# Altium schematic sheet STM32F407Demo.SchDoc
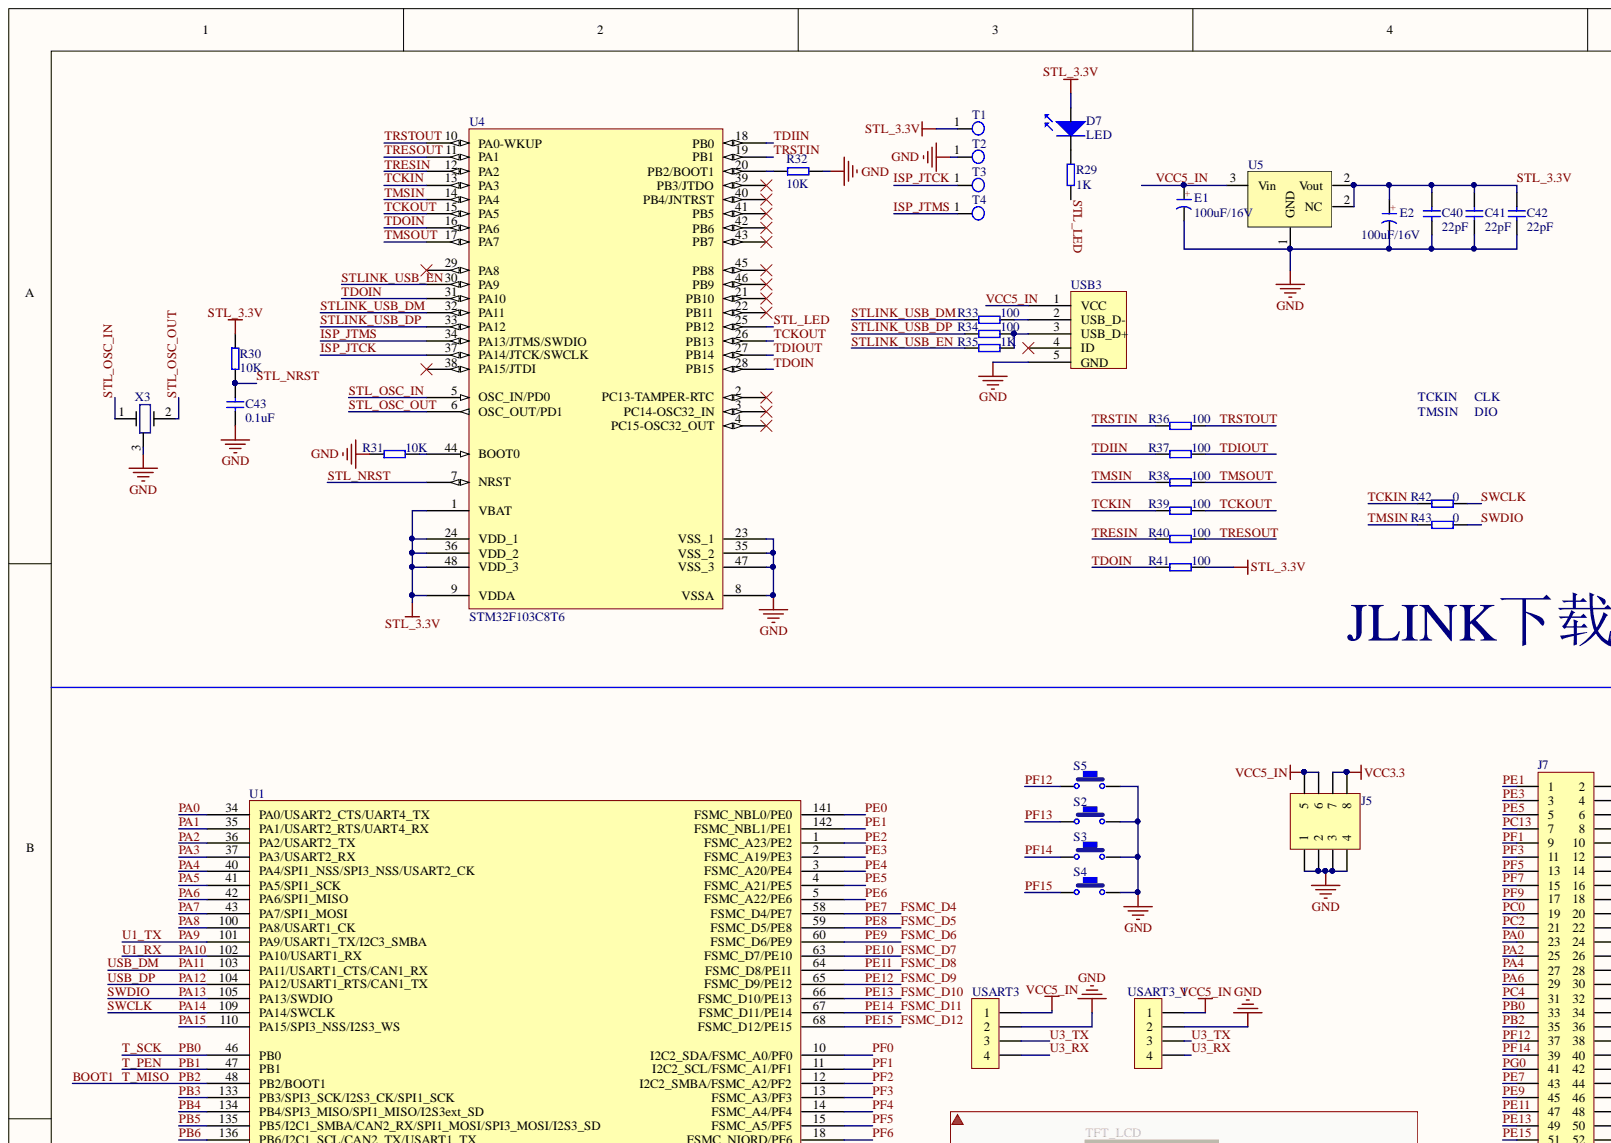
[diagram p0: STM32F407Demo.SchDoc, top left]
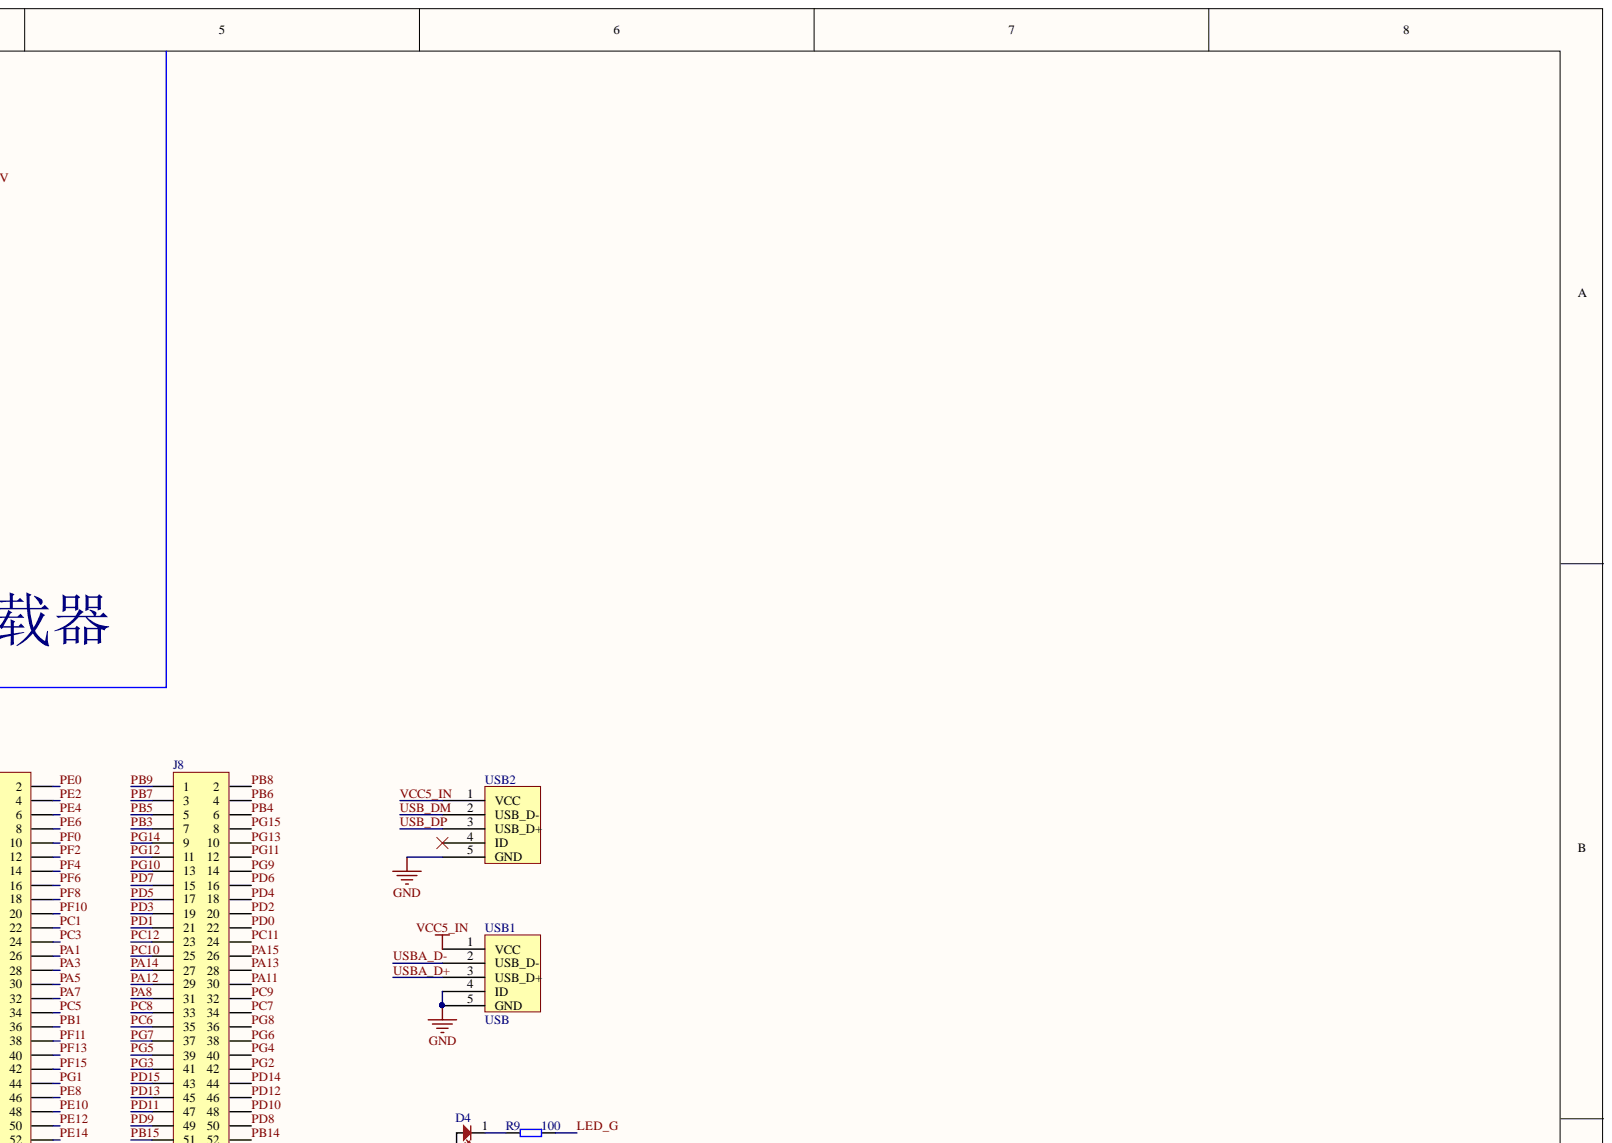
[diagram p1: STM32F407Demo.SchDoc, top right]
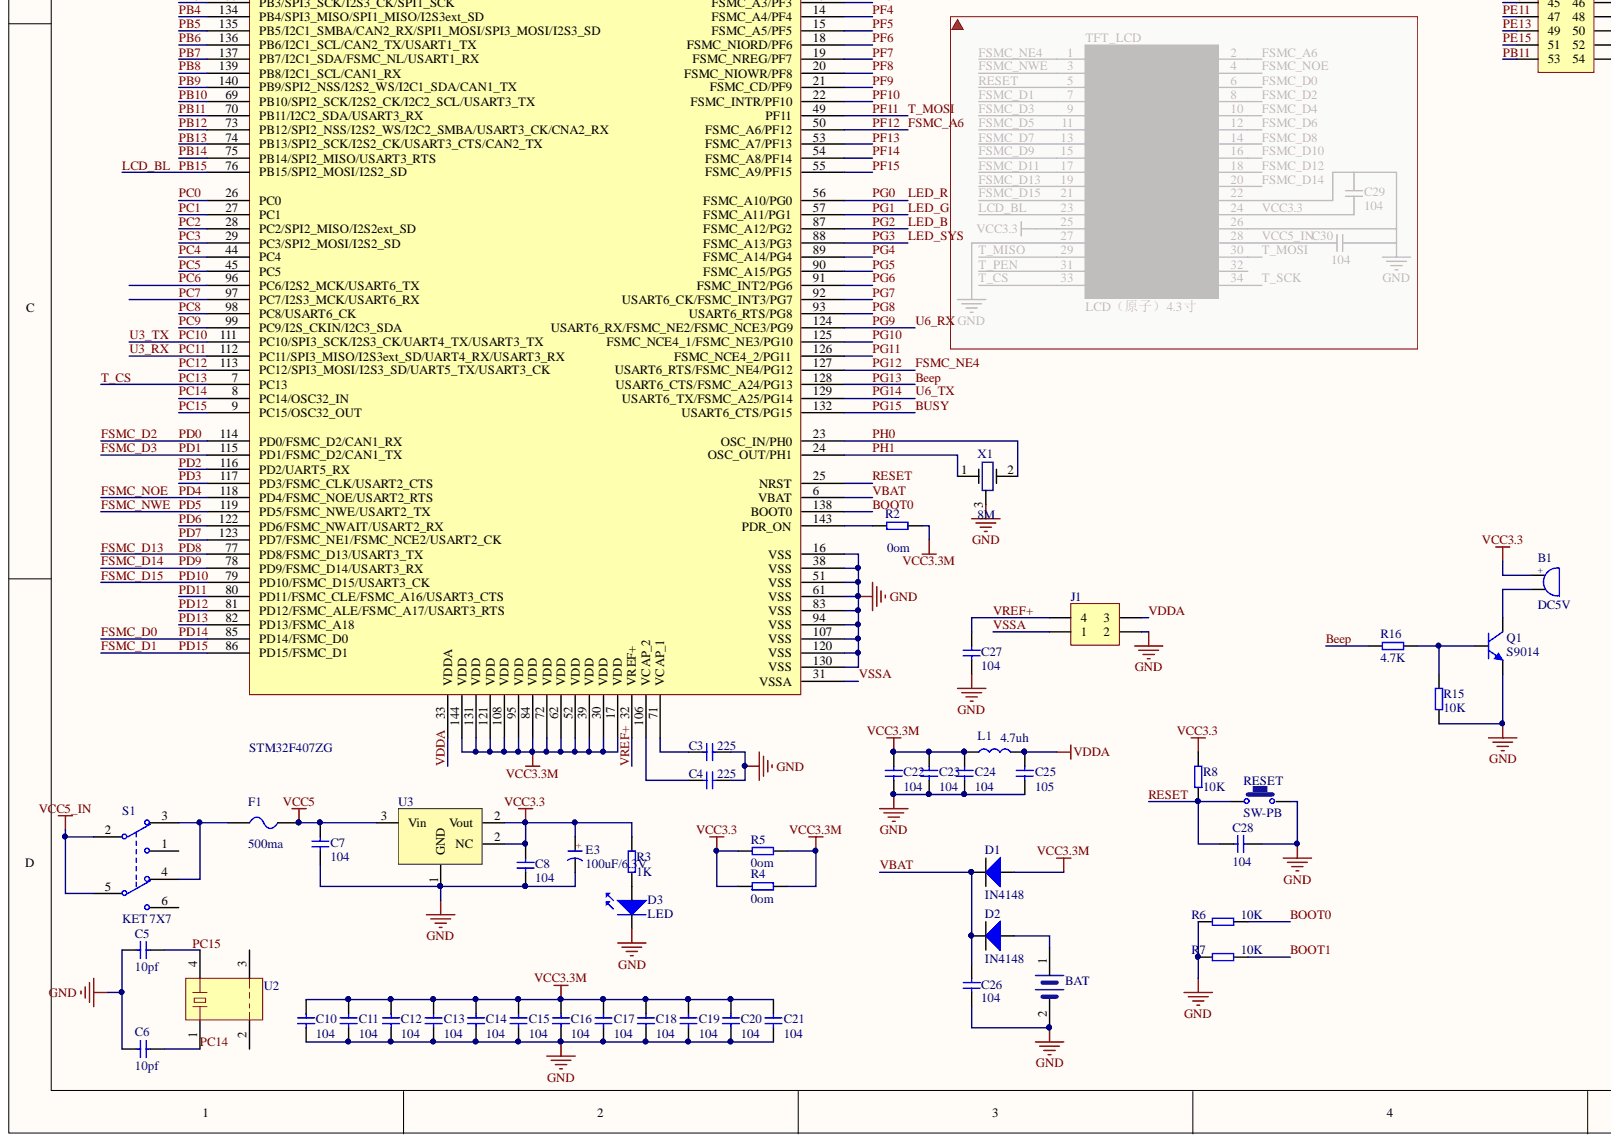
[diagram p2: STM32F407Demo.SchDoc, bottom left]
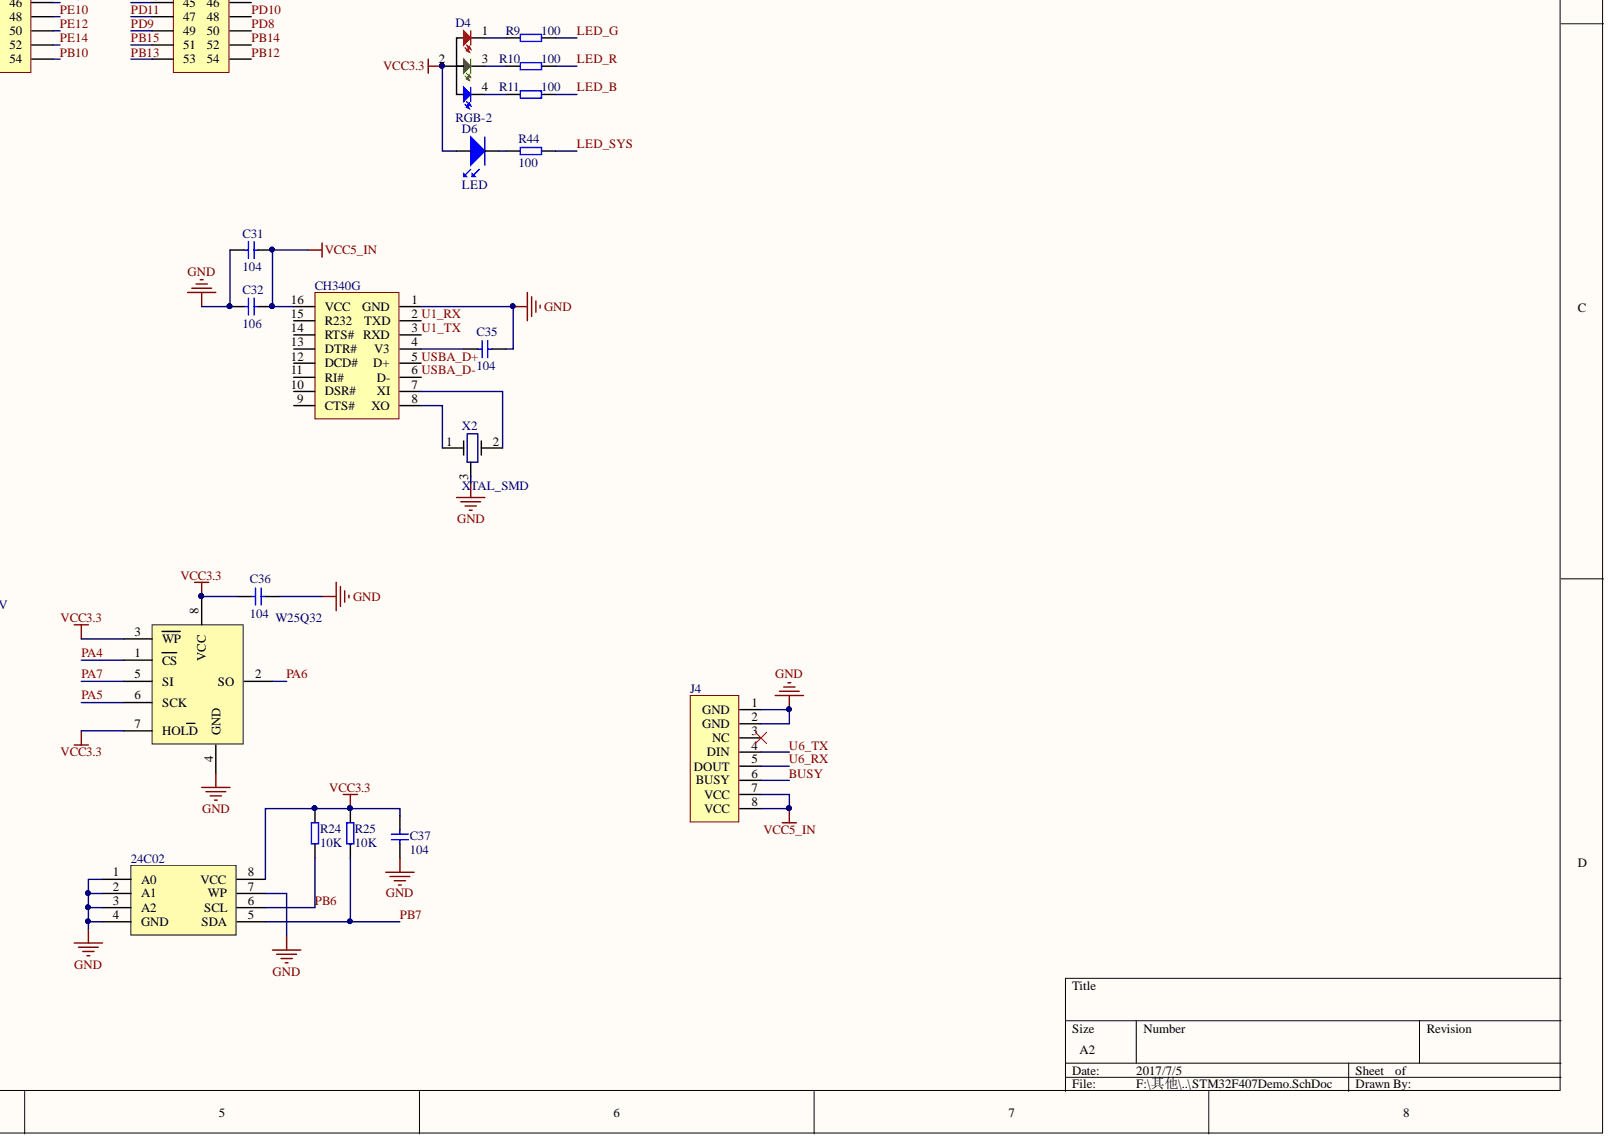
[diagram p3: STM32F407Demo.SchDoc, bottom right]

## Parts
24C02  (no value)  [SOP_8]
B1  DC5V  [BEEP 5x9x5.5]
BAT  (no value)  [BATM]
C3  225  [0603C]
C4  225  [0603C]
C5  10pf  [0603C]
C6  10pf  [0603C]
C7  104  [0603C]
C8  104  [0603C]
C10  104  [0603C]
C11  104  [0603C]
C12  104  [0603C]
C13  104  [0603C]
C14  104  [0603C]
C15  104  [0603C]
C16  104  [0603C]
C17  104  [0603C]
C18  104  [0603C]
C19  104  [0603C]
C20  104  [0603C]
C21  104  [0603C]
C22  104  [0603C]
C23  104  [0603C]
C24  104  [0603C]
C25  105  [0603C]
C26  104  [0603C]
C27  104  [0603C]
C28  104  [0603C]
C31  104  [0603C]
C32  106  [0603C]
C35  104  [0603C]
C36  104  [0603C]
C37  104  [0603C]
C40  22pF  [0603C]
C41  22pF  [0603C]
C42  22pF  [0603C]
C43  0.1uF  [0603C]
CH340G  (no value)  [SOP16_N]
D1  IN4148  [1206C]
D2  IN4148  [1206C]
D3  LED  [0603D]
D4  RGB-2  [RGB-2]
D6  LED  [0603D]
D7  LED  [0603D]
E1  100uF/16V  [CD C(6032)]
E2  100uF/16V  [CD C(6032)]
E3  100uF/6.3V  [CD C(6032)]
F1  500ma  [FU 0805]
J1  Header2*2  [Heaer2*2]  (value not drawn)
J4  LCD_Header*8  [PH2.0 S-W-8P]  (value not drawn)
J5  Header2*4  [Header 2*4]  (value not drawn)
J7  Header54  [Header54]  (value not drawn)
J8  Header54  [Header54]  (value not drawn)
L1  4.7uh  [0805L]
Q1  S9014  [SOT23-3N]
R2  0om  [0603R]
R3  1K  [0603R]
R4  0om  [0603R]
R5  0om  [0603R]
R6  10K  [0603R]
R7  10K  [0603R]
R8  10K  [0603R]
R9  100  [0603R]
R10  100  [0603R]
R11  100  [0603R]
R15  10K  [0603R]
R16  4.7K  [0603R]
R24  10K  [0603R]
R25  10K  [0603R]
R29  1K  [0603R]
R30  10K  [0603R]
R31  10K  [0603R]
R32  10K  [0603R]
R33  100  [0603R]
R34  100  [0603R]
R35  1K  [0603R]
R36  100  [0603R]
R37  100  [0603R]
R38  100  [0603R]
R39  100  [0603R]
R40  100  [0603R]
R41  100  [0603R]
R42  0  [0603R]
R43  0  [0603R]
R44  100  [0603R]
RESET  SW-PB  [Switch]
S1  KET 7X7  [KFT DIP-7X7]
S2  SW-PB  [Switch]  (value not drawn)
S3  SW-PB  [Switch]  (value not drawn)
S4  SW-PB  [Switch]  (value not drawn)
S5  SW-PB  [Switch]  (value not drawn)
T1  Test_Point  [TEST 1.2]  (value not drawn)
T2  Test_Point  [TEST 1.2]  (value not drawn)
T3  Test_Point  [TEST 1.2]  (value not drawn)
T4  Test_Point  [TEST 1.2]  (value not drawn)
U1  STM32F407ZG  [LQFP144 20X20_M]
U2  (no value)  [MC-306]
U3  AMS1117  [SOT223_N]  (value not drawn)
U4  STM32F103C8T6  [LQFP48 7X7_M]
U5  AMS1117  [SOT223_N]  (value not drawn)
USART3  Header4  [SMD-1.25]  (value not drawn)
USART3_1  Header4  [贴片焊盘 1.27]  (value not drawn)
USB1  USB  [USB_Micro]
USB2  USB  [USB_Micro]
USB3  USB  [USB_Micro]
W25Q32  (no value)  [SOP_8]
X1  8M  [XTAL_SMD]
X2  XTAL_SMD  [XTAL_SMD]
X3  XTAL_SMD  [XTAL_SMD]  (value not drawn)

## Nets
GND: 24C02.1(A0) 24C02.2(A1) 24C02.3(A2) 24C02.4(GND) 24C02.7(WP) BAT.2 C3.1 C4.1 C5.1 C6.1 C7.1 C8.1 C10.1 C11.1 C12.1 C13.1 C14.1 C15.1 C16.1 C17.1 C18.1 C19.1 C20.1 C21.1 C22.1 C23.1 C24.1 C25.1 C26.1 C27.1 C28.1 C31.2 C32.2 C35.1 C36.2 C37.1 C40.1 C41.1 C42.1 C43.1 CH340G.1(GND) D3.2 E1.2 E2.2 E3.2 J1.2 J4.1(GND) J4.2(GND) J5.1 J5.2 J5.3 J5.4 Q1.2 R6.2 R7.1 R15.1 R31.1 R32.2 RESET.2 S2.2 S3.2 S4.2 S5.2 T2.1 U1.16(VSS) U1.38(VSS) U1.51(VSS) U1.61(VSS) U1.83(VSS) U1.94(VSS) U1.107(VSS) U1.120(VSS) U1.130(VSS) U3.1 U4.8(VSSA) U4.23(VSS_1) U4.35(VSS_2) U4.47(VSS_3) U5.1 USART3.2 USART3_1.2 USB1.4(ID) USB1.5(GND) USB2.5(GND) USB3.5(GND) W25Q32.4(GND) X1.3 X2.3 X3.3
VCC3.3: 24C02.8(VCC) B1.1 C8.2 C36.1 C37.2 D4.2 D6.1 E3.1 J5.7 J5.8 R3.2 R4.1 R5.2 R8.2 R24.1 R25.1 U3.2 W25Q32.3(WP) W25Q32.7(HOLD) W25Q32.8(VCC)
VCC3.3M: C10.2 C11.2 C12.2 C13.2 C14.2 C15.2 C16.2 C17.2 C18.2 C19.2 C20.2 C21.2 C22.2 C23.2 C24.2 D1.1 L1.1 R2.2 R4.2 R5.1 U1.17(VDD) U1.30(VDD) U1.39(VDD) U1.52(VDD) U1.62(VDD) U1.72(VDD) U1.84(VDD) U1.95(VDD) U1.108(VDD) U1.121(VDD) U1.131(VDD) U1.144(VDD)
VCC5: C7.2 F1.2 U3.3
BEEP: J8.10 R16.1 U1.128(USART6_CTS/FSMC_A24/PG13)
BOOT0: R6.1 U1.138(BOOT0)
BOOT1: J7.35 R7.2 U1.48(PB2/BOOT1)
BUSY: J4.6(BUSY) J8.8 U1.132(USART6_CTS/PG15)
FSMC_A6: J7.37 S5.1 U1.50(FSMC_A6/PF12)
FSMC_D0: J8.44 U1.85(PD14/FSMC_D0)
FSMC_D1: J8.43 U1.86(PD15/FSMC_D1)
FSMC_D2: J8.22 U1.114(PD0/FSMC_D2/CAN1_RX)
FSMC_D3: J8.21 U1.115(PD1/FSMC_D2/CAN1_TX)
FSMC_D4: J7.43 U1.58(FSMC_D4/PE7)
FSMC_D5: J7.46 U1.59(FSMC_D5/PE8)
FSMC_D6: J7.45 U1.60(FSMC_D6/PE9)
FSMC_D7: J7.48 U1.63(FSMC_D7/PE10)
FSMC_D8: J7.47 U1.64(FSMC_D8/PE11)
FSMC_D9: J7.50 U1.65(FSMC_D9/PE12)
FSMC_D10: J7.49 U1.66(FSMC_D10/PE13)
FSMC_D11: J7.52 U1.67(FSMC_D11/PE14)
FSMC_D12: J7.51 U1.68(FSMC_D12/PE15)
FSMC_D13: J8.50 U1.77(PD8/FSMC_D13/USART3_TX)
FSMC_D14: J8.49 U1.78(PD9/FSMC_D14/USART3_RX)
FSMC_D15: J8.48 U1.79(PD10/FSMC_D15/USART3_CK)
FSMC_NE4: J8.11 U1.127(USART6_RTS/FSMC_NE4/PG12)
FSMC_NOE: J8.18 U1.118(PD4/FSMC_NOE/USART2_RTS)
FSMC_NWE: J8.17 U1.119(PD5/FSMC_NWE/USART2_TX)
ISP_JTCK: T3.1 U4.37(PA14/JTCK/SWCLK)
ISP_JTMS: T4.1 U4.34(PA13/JTMS/SWDIO)
LCD_BL: J8.51 U1.76(PB15/SPI2_MOSI/I2S2_SD)
LED_B: J8.42 R11.1 U1.87(FSMC_A12/PG2)
LED_G: J7.44 R9.1 U1.57(FSMC_A11/PG1)
LED_R: J7.41 R10.1 U1.56(FSMC_A10/PG0)
LED_SYS: J8.41 R44.2 U1.88(FSMC_A13/PG3)
PA0: J7.23 U1.34(PA0/USART2_CTS/UART4_TX)
PA1: J7.26 U1.35(PA1/USART2_RTS/UART4_RX)
PA2: J7.25 U1.36(PA2/USART2_TX)
PA3: J7.28 U1.37(PA3/USART2_RX)
PA4: J7.27 U1.40(PA4/SPI1_NSS/SPI3_NSS/USART2_CK) W25Q32.1(CS)
PA5: J7.30 U1.41(PA5/SPI1_SCK) W25Q32.6(SCK)
PA6: J7.29 U1.42(PA6/SPI1_MISO) W25Q32.2(SO)
PA7: J7.32 U1.43(PA7/SPI1_MOSI) W25Q32.5(SI)
PA8: J8.31 U1.100(PA8/USART1_CK)
PA9: CH340G.3(RXD) U1.101(PA9/USART1_TX/I2C3_SMBA)
PA10: CH340G.2(TXD) U1.102(PA10/USART1_RX)
PA11: J8.30 U1.103(PA11/USART1_CTS/CAN1_RX) USB2.2(USB_D-)
PA12: J8.29 U1.104(PA12/USART1_RTS/CAN1_TX) USB2.3(USB_D+)
PA13: J8.28 R43.2 U1.105(PA13/SWDIO)
PA14: J8.27 R42.2 U1.109(PA14/SWCLK)
PA15: J8.26 U1.110(PA15/SPI3_NSS/I2S3_WS)
PB0: J7.33 U1.46(PB0)
PB1: J7.36 U1.47(PB1)
PB3: J8.7 U1.133(PB3/SPI3_SCK/I2S3_CK/SPI1_SCK)
PB4: J8.6 U1.134(PB4/SPI3_MISO/SPI1_MISO/I2S3ext_SD)
PB5: J8.5 U1.135(PB5/I2C1_SMBA/CAN2_RX/SPI1_MOSI/SPI3_MOSI/I2S3_SD)
PB6: 24C02.6(SCL) J8.4 R24.2 U1.136(PB6/I2C1_SCL/CAN2_TX/USART1_TX)
PB7: 24C02.5(SDA) J8.3 R25.2 U1.137(PB7/I2C1_SDA/FSMC_NL/USART1_RX)
PB8: J8.2 U1.139(PB8/I2C1_SCL/CAN1_RX)
PB9: J8.1 U1.140(PB9/SPI2_NSS/I2S2_WS/I2C1_SDA/CAN1_TX)
PB10: J7.54 U1.69(PB10/SPI2_SCK/I2S2_CK/I2C2_SCL/USART3_TX)
PB11: J7.53 U1.70(PB11/I2C2_SDA/USART3_RX)
PB12: J8.54 U1.73(PB12/SPI2_NSS/I2S2_WS/I2C2_SMBA/USART3_CK/CNA2_RX)
PB13: J8.53 U1.74(PB13/SPI2_SCK/I2S2_CK/USART3_CTS/CAN2_TX)
PB14: J8.52 U1.75(PB14/SPI2_MISO/USART3_RTS)
PC0: J7.19 U1.26(PC0)
PC1: J7.22 U1.27(PC1)
PC2: J7.21 U1.28(PC2/SPI2_MISO/I2S2ext_SD)
PC3: J7.24 U1.29(PC3/SPI2_MOSI/I2S2_SD)
PC4: J7.31 U1.44(PC4)
PC5: J7.34 U1.45(PC5)
PC6: J8.35 U1.96(PC6/I2S2_MCK/USART6_TX)
PC7: J8.34 U1.97(PC7/I2S3_MCK/USART6_RX)
PC8: J8.33 U1.98(PC8/USART6_CK)
PC9: J8.32 U1.99(PC9/I2S_CKIN/I2C3_SDA)
PC10: J8.25 U1.111(PC10/SPI3_SCK/I2S3_CK/UART4_TX/USART3_TX) USART3.3 USART3_1.3
PC11: J8.24 U1.112(PC11/SPI3_MISO/I2S3ext_SD/UART4_RX/USART3_RX) USART3.4 USART3_1.4
PC12: J8.23 U1.113(PC12/SPI3_MOSI/I2S3_SD/UART5_TX/USART3_CK)
PC13: J7.7 U1.7(PC13)
PC14: C6.2 U1.8(PC14/OSC32_IN) U2.1
PC15: C5.2 U1.9(PC15/OSC32_OUT) U2.4
PD2: J8.20 U1.116(PD2/UART5_RX)
PD3: J8.19 U1.117(PD3/FSMC_CLK/USART2_CTS)
PD6: J8.16 U1.122(PD6/FSMC_NWAIT/USART2_RX)
PD7: J8.15 U1.123(PD7/FSMC_NE1/FSMC_NCE2/USART2_CK)
PD11: J8.47 U1.80(PD11/FSMC_CLE/FSMC_A16/USART3_CTS)
PD12: J8.46 U1.81(PD12/FSMC_ALE/FSMC_A17/USART3_RTS)
PD13: J8.45 U1.82(PD13/FSMC_A18)
PE0: J7.2 U1.141(FSMC_NBL0/PE0)
PE1: J7.1 U1.142(FSMC_NBL1/PE1)
PE2: J7.4 U1.1(FSMC_A23/PE2)
PE3: J7.3 U1.2(FSMC_A19/PE3)
PE4: J7.6 U1.3(FSMC_A20/PE4)
PE5: J7.5 U1.4(FSMC_A21/PE5)
PE6: J7.8 U1.5(FSMC_A22/PE6)
PF0: J7.10 U1.10(I2C2_SDA/FSMC_A0/PF0)
PF1: J7.9 U1.11(I2C2_SCL/FSMC_A1/PF1)
PF2: J7.12 U1.12(I2C2_SMBA/FSMC_A2/PF2)
PF3: J7.11 U1.13(FSMC_A3/PF3)
PF4: J7.14 U1.14(FSMC_A4/PF4)
PF5: J7.13 U1.15(FSMC_A5/PF5)
PF6: J7.16 U1.18(FSMC_NIORD/PF6)
PF7: J7.15 U1.19(FSMC_NREG/PF7)
PF8: J7.18 U1.20(FSMC_NIOWR/PF8)
PF9: J7.17 U1.21(FSMC_CD/PF9)
PF10: J7.20 U1.22(FSMC_INTR/PF10)
PF11: J7.38 U1.49(PF11)
PF13: J7.40 S2.1 U1.53(FSMC_A7/PF13)
PF14: J7.39 S3.1 U1.54(FSMC_A8/PF14)
PF15: J7.42 S4.1 U1.55(FSMC_A9/PF15)
PG4: J8.40 U1.89(FSMC_A14/PG4)
PG5: J8.39 U1.90(FSMC_A15/PG5)
PG6: J8.38 U1.91(FSMC_INT2/PG6)
PG7: J8.37 U1.92(USART6_CK/FSMC_INT3/PG7)
PG8: J8.36 U1.93(USART6_RTS/PG8)
PG9: J4.5(DOUT) J8.14 U1.124(USART6_RX/FSMC_NE2/FSMC_NCE3/PG9)
PG10: J8.13 U1.125(FSMC_NCE4_1/FSMC_NE3/PG10)
PG11: J8.12 U1.126(FSMC_NCE4_2/PG11)
PG14: J4.4(DIN) J8.9 U1.129(USART6_TX/FSMC_A25/PG14)
PH0: U1.23(OSC_IN/PH0) X1.2
PH1: U1.24(OSC_OUT/PH1) X1.1
RESET: C28.2 R8.1 RESET.1 U1.25(NRST)
STL_3.3V: C40.2 C41.2 C42.2 D7.1 E2.1 R30.1 R41.2 T1.1 U4.1(VBAT) U4.9(VDDA) U4.24(VDD_1) U4.36(VDD_2) U4.48(VDD_3) U5.2
STL_LED: R29.1 U4.25(PB12)
STL_NRST: C43.2 R30.2 U4.7(NRST)
STL_OSC_IN: U4.5(OSC_IN/PD0) X3.1
STL_OSC_OUT: U4.6(OSC_OUT/PD1) X3.2
STLINK_USB_DM: R33.1 U4.32(PA11)
STLINK_USB_DP: R34.1 U4.33(PA12)
STLINK_USB_EN: R35.1 U4.30(PA9)
TCKIN: R39.1 R42.1 U4.13(PA3)
TCKOUT: R39.2 U4.15(PA5) U4.26(PB13)
TDIIN: R37.1 U4.18(PB0)
TDIOUT: R37.2 U4.27(PB14)
TDOIN: R41.1 U4.16(PA6) U4.28(PB15) U4.31(PA10)
TMSIN: R38.1 R43.1 U4.14(PA4)
TMSOUT: R38.2 U4.17(PA7)
TRESIN: R40.1 U4.12(PA2)
TRESOUT: R40.2 U4.11(PA1)
TRSTIN: R36.1 U4.19(PB1)
TRSTOUT: R36.2 U4.10(PA0-WKUP)
USBA_D+: CH340G.5(D+) USB1.3(USB_D+)
USBA_D-: CH340G.6(D-) USB1.2(USB_D-)
VBAT: C26.2 D1.2 D2.2 U1.6(VBAT)
VCC5_IN: C31.1 C32.1 CH340G.16(VCC) E1.1 J4.7(VCC) J4.8(VCC) J5.5 J5.6 S1.2 S1.5 U5.3 USART3.1 USART3_1.1 USB1.1(VCC) USB2.1(VCC) USB3.1(VCC)
VDDA: C25.2 J1.3 L1.2 U1.33(VDDA)
VREF+: C27.2 J1.4 U1.32(VREF+)
VSSA: J1.1 U1.31(VSSA)
NetB1_2: B1.2 Q1.3
NetBAT_1: BAT.1 D2.1
NetC3_2: C3.2 U1.71(VCAP_1)
NetC4_2: C4.2 U1.106(VCAP_2)
NetC35_2: C35.2 CH340G.4(V3)
NetCH340G_7: CH340G.7(XI) X2.2
NetCH340G_8: CH340G.8(XO) X2.1
NetD3_1: D3.1 R3.1
NetD4_1: D4.1 R9.2
NetD4_3: D4.3 R10.2
NetD4_4: D4.4 R11.2
NetD6_2: D6.2 R44.1
NetD7_2: D7.2 R29.2
NetF1_1: F1.1 S1.3 S1.4
NetQ1_1: Q1.1 R15.2 R16.2
NetR2_1: R2.1 U1.143(PDR_ON)
NetR31_2: R31.2 U4.44(BOOT0)
NetR32_1: R32.1 U4.20(PB2/BOOT1)
NetR33_2: R33.2 USB3.2(USB_D-)
NetR34_2: R34.2 R35.2 USB3.3(USB_D+)

Not connected: CH340G 9(CTS#) 10(DSR#) 11(RI#) 12(DCD#) 13(DTR#) 14(RTS#) 15(R232); J4 3(NC); S1 1 6; U2 2 3; U4 2(PC13-TAMPER-RTC) 3(PC14-OSC32_IN) 4(PC15-OSC32_OUT) 21(PB10) 22(PB11) 29(PA8) 38(PA15/JTDI) 39(PB3/JTDO) 40(PB4/JNTRST) 41(PB5) 42(PB6) 43(PB7) 45(PB8) 46(PB9); USB2 4(ID); USB3 4(ID)

## Library data of the parts
24C02 = 24CXX: Component Kind: Standard; Library Name: SWLiB.SchLib
B1 = BEEP: 蜂鸣器; 版权归属: 源创客; BOM名称: 蜂鸣器; Component Kind: Standard; Library Name: 未分类器件.SchLib; 学习资源: www.ooocke.com
BAT = BAT: Component Kind: Standard; Library Name: SWLiB.SchLib
C3-C8 C10-C28 C31 C32 C35-C37 C40-C43 = Cap(0603): Component Kind: Standard; Library Name: SWLiB.SchLib
CH340G = CH340G: Component Kind: Standard; Library Name: SWLiB.SchLib
D1 D2 = DIODE: Component Kind: Standard; Library Name: SWLiB.SchLib
E1-E3 = C-CD: 贴片钽电容; 版权归属: 源创客; BOM名称: 贴片钽电容; Component Kind: Standard; Library Name: 电阻-电容-电感.SchLib; 学习资源: www.ooocke.com
F1 = FU: 贴片保险丝; 版权归属: 源创客; BOM名称: 贴片保险丝; Component Kind: Standard; Library Name: 保险丝-晶振.SchLib; 学习资源: www.ooocke.com
L1 = 电感（0603）: Component Kind: Standard; Library Name: SWLiB.SchLib
Q1 = 9014-SMD: 低噪放大-NPN型; 属性: 50V 0.1A 0.4W 150MHZ; 版权归属: 源创客; BOM名称: 低噪放大-NPN型; Component Kind: Standard; Library Name: 三极管.SchLib; 学习资源: www.ooocke.com
R2-R11 R15 R16 R24 R25 R29-R44 = Resistor(0603): Component Kind: Standard; Library Name: SWLiB.SchLib
S1 = KET 7X7: 7*7自锁开关; 版权归属: 源创客; BOM名称: 7*7自锁开关; Component Kind: Standard; Library Name: 按键-开关.SchLib; 学习资源: www.ooocke.com
U1 = STM32F407ZG: Flaah:1024/RAM:192/IO:114; BOM名称: 32位ST单片机; 版权归属: 源创客; Component Kind: Standard; Library Name: STM32系列单片机.SchLib; 学习资源: www.ooocke.com
U2 = CY_32.768k: Component Kind: Standard; Library Name: SWLiB.SchLib
U4 = STM32F103C8T6: Flaah:64/RAM:20/IO:36; BOM名称: 32位STM单片机; 版权归属: 源创客; Component Kind: Standard; Library Name: STM32系列单片机.SchLib; 学习资源: www.ooocke.com
USB1-USB3 = Mini_Usb: Component Kind: Standard; Library Name: SWLiB.SchLib
W25Q32 = W25Q32: Component Kind: Standard; Library Name: SWLiB.SchLib
X1 = XTAL_SMD: Component Kind: Standard; Library Name: SWLiB.SchLib
D3 D6 D7 = LED: Component Kind: Standard; Library Name: SWLiB.SchLib
D4 = RGB-2: Component Kind: Standard; Library Name: SWLiB.SchLib
J1 = Header2*2: Component Kind: Standard; Library Name: SWLiB.SchLib
J4 = LCD_Header*8: Component Kind: Standard; Library Name: SWLiB.SchLib
J5 = Header2*4: Component Kind: Standard; Library Name: SWLiB.SchLib
J7 J8 = Header54: Component Kind: Standard; Library Name: SWLiB.SchLib
RESET S2-S5 = SW-PB: Component Kind: Standard; Library Name: SWLiB.SchLib
T1-T4 = Test_Point: Component Kind: Standard; Library Name: SWLiB.SchLib
U3 U5 = AMS1117: Component Kind: Standard; Library Name: SWLiB.SchLib
USART3 USART3_1 = Header4: Component Kind: Standard; Library Name: SWLiB.SchLib
X2 X3 = XTAL_SMD: Component Kind: Standard; Library Name: SWLiB.SchLib
